# Altium schematic sheet Sheet1.SchDoc(Sheet1)
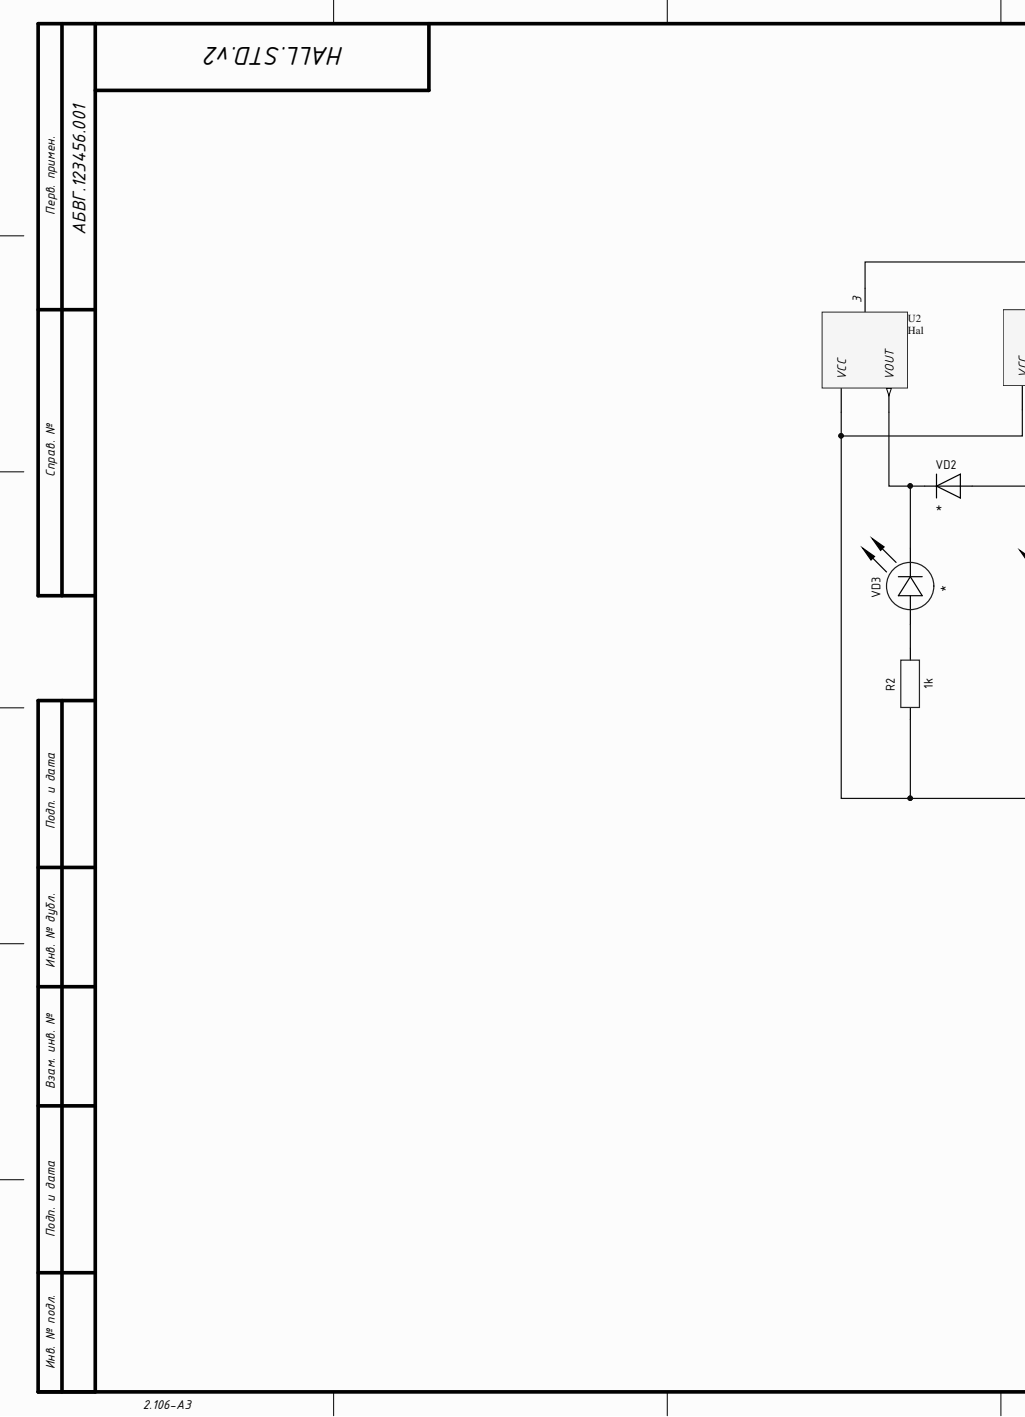
[diagram p0: Sheet1.SchDoc, left]
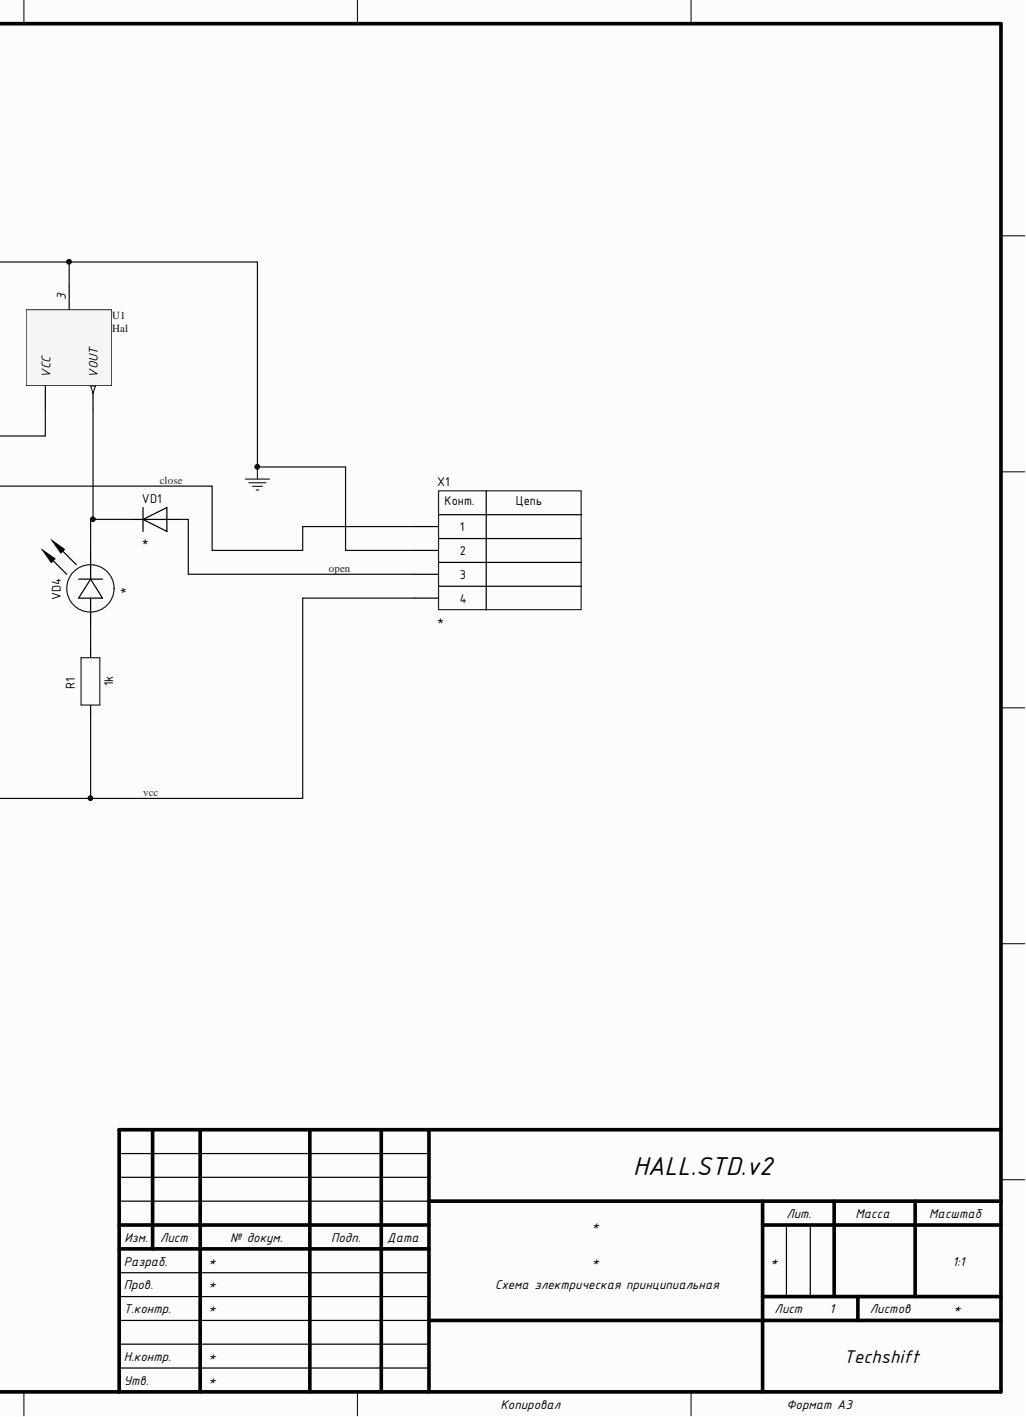
[diagram p1: Sheet1.SchDoc, right]

## Parts
R1  1k  [CH0805R]
R2  1k  [CH0805R]
U1  Hal  [A1103ELHLT-T]
U2  Hal  [A1103ELHLT-T]
VD1  (no value)  [SOD123]
VD2  (no value)  [SOD123]
VD3  (no value)  [CH0805LB]
VD4  (no value)  [CH0805LR]
X1  (no value)  [CON: PLS-4(90)]

## Nets
GND: U1.3 U2.3 X1.2
close: VD2.1 X1.1
open: VD1.1 X1.3
vcc: R1.1 R2.1 U1.1 U2.1 X1.4
NetR1_2: R1.2 VD4.1
NetR2_2: R2.2 VD3.1
NetU1_2: U1.2 VD1.2 VD4.2
NetU2_2: U2.2 VD2.2 VD3.2

## Library data of the parts
R1 R2 = Resistor: Value: 1k; Library Name: Discrete.SchLib; Component Kind: Standard
VD1 VD2 = Diod: Library Name: Diods.IntLib; Component Kind: Standard
VD3 VD4 = LED: Library Name: Discrete.SchLib; Component Kind: Standard
X1 = 4-Connector: Library Name: Connectors.IntLib; Component Kind: Standard
U1 U2 = Hal: Library Name: Integrated_Library.IntLib; Component Kind: Standard
